# Altium schematic sheet AD9363 OSCILLATORS.SchDoc
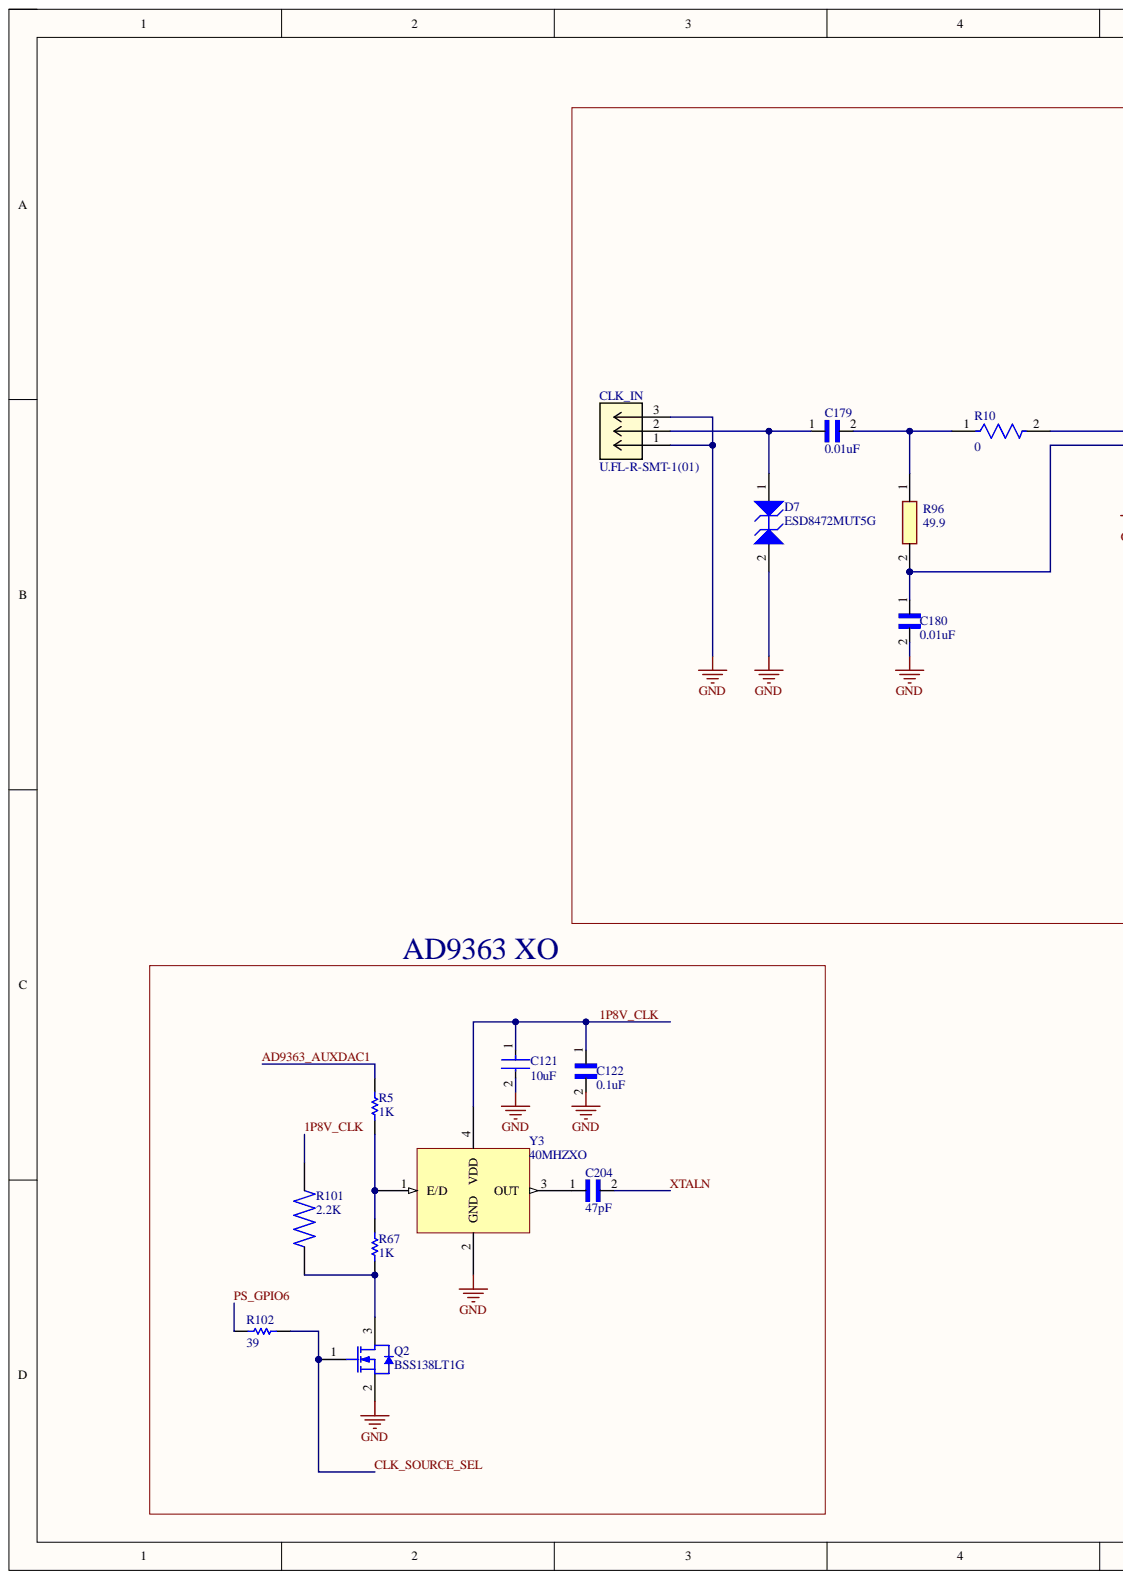
[diagram p0: AD9363 OSCILLATORS.SchDoc, left]
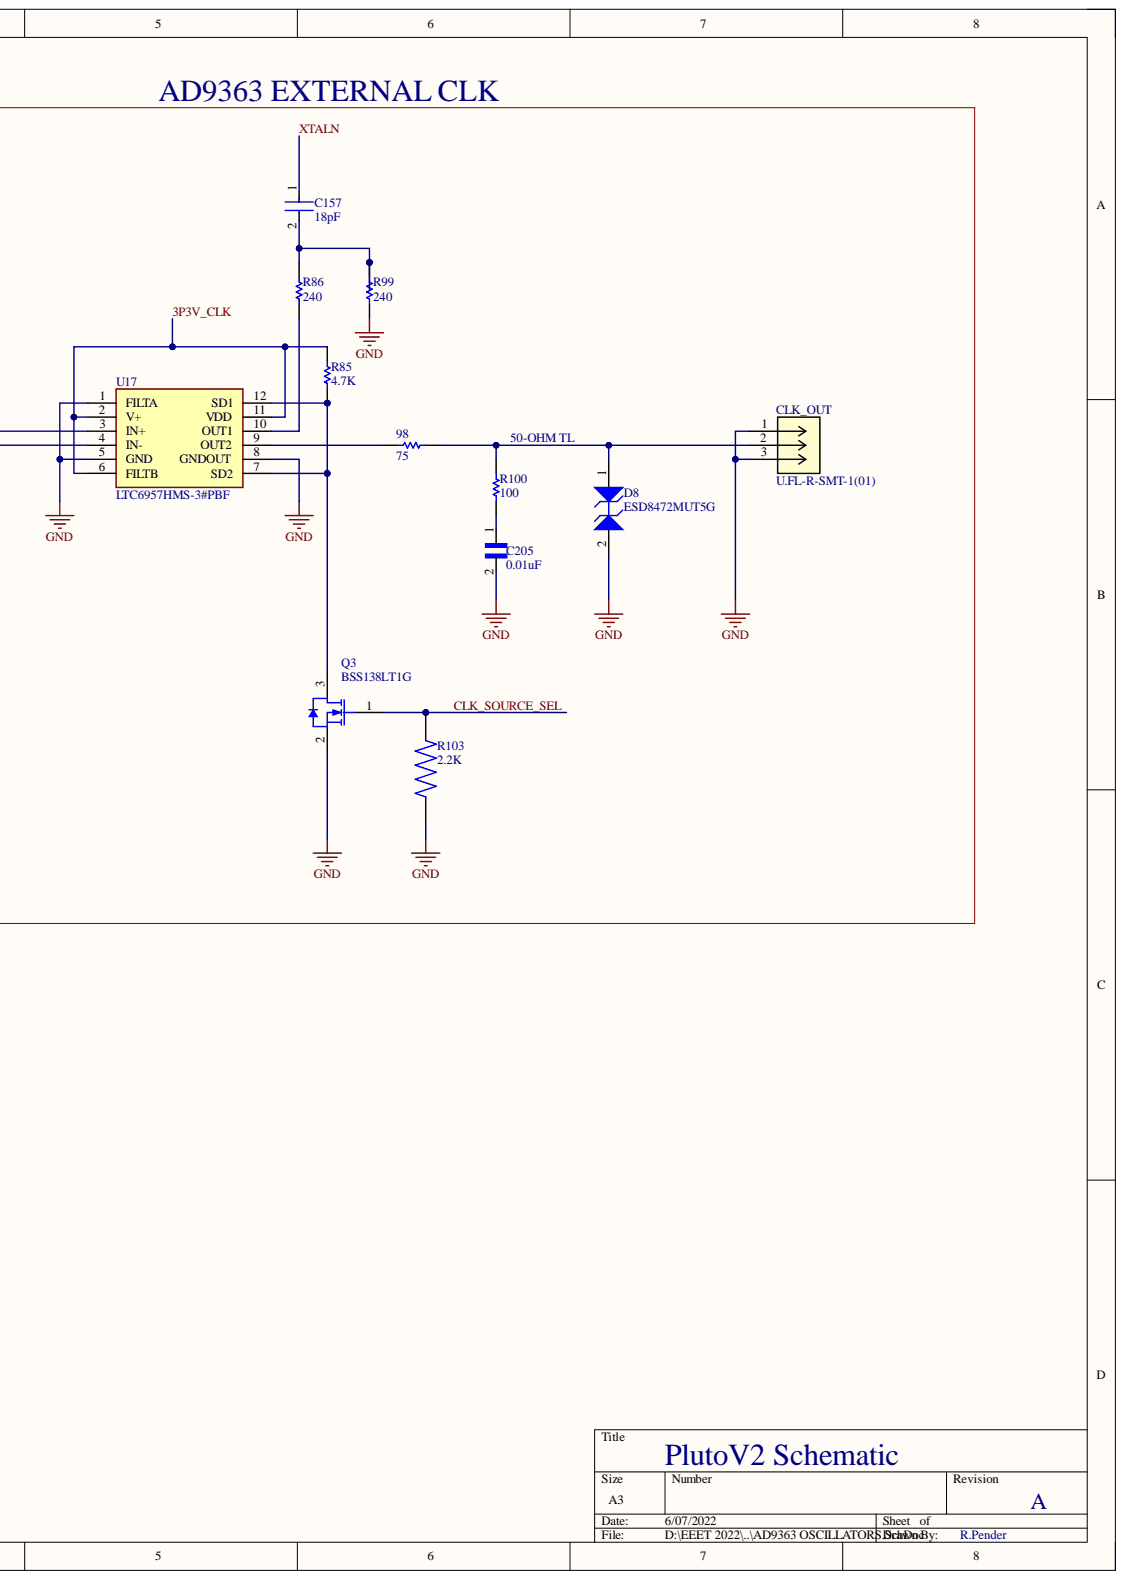
[diagram p1: AD9363 OSCILLATORS.SchDoc, right]

## Parts
98  75  [2-0402]
C121  10uF  [FP-GRM188-0_1-e0_2_0_55-IPC_C]
C122  0.1uF  [CAPC0603X33N]
C157  18pF  [FP-GRM033-0_03-IPC_A]
C179  0.01uF  [CAPC0603X33N]
C180  0.01uF  [CAPC0603X33N]
C204  47pF  [CAPC1005X55N]
C205  0.01uF  [CAPC0603X33N]
CLK_IN  U.FL-R-SMT-1(01)  [CONN_-SMT-1(01)]
CLK_OUT  U.FL-R-SMT-1(01)  [CONN_-SMT-1(01)]
D7  ESD8472MUT5G  [ONSC-X3DFN-2-152AF-01_V]
D8  ESD8472MUT5G  [ONSC-X3DFN-2-152AF-01_V]
Q2  BSS138LT1G  [ONSC-SOT-23-3-318-08_V]
Q3  BSS138LT1G  [ONSC-SOT-23-3-318-08_V]
R5  1K  [RESC0603X26X15NL03T05]
R10  0  [FP-ERJ1GN-IPC_A]
R67  1K  [2-0402]
R85  4.7K  [2-0402]
R86  240  [2-0402]
R96  49.9  [RESC1608X46N]
R99  240  [2-0402]
R100  100  [2-0402]
R101  2.2K  [RESC1005X35N]
R102  39  [2-0402]
R103  2.2K  [RESC1005X35N]
U17  LTC6957HMS-3#PBF  [SOP65P490X110-12N]
Y3  40MHZXO

## Nets
GND: C121.2 C122.2 C180.2 C205.2 CLK_IN.1 CLK_IN.3 CLK_OUT.1 CLK_OUT.3 D7.2 D8.2 Q2.2 Q3.2 R99.1 R103.2 U17.1(FILTA) U17.5(GND) U17.8(GNDOUT) Y3.2(GND)
1P8V_CLK: C121.1 C122.1 R101.2 Y3.4(VDD)
3P3V_CLK: R85.2 U17.2(V+) U17.6(FILTB) U17.11(VDD)
AD9363_AUXDAC1: R5.1
CLK_SOURCE_SEL: Q2.1 Q3.1 R102.2 R103.1
PS_GPIO6: R102.1
XTALN: C157.1 C204.2
Net98_1: 98.1 U17.9(OUT2)
Net98_2: 98.2 CLK_OUT.2 D8.1 R100.1
NetC157_2: C157.2 R86.2 R99.2
NetC179_1: C179.1 CLK_IN.2 D7.1
NetC179_2: C179.2 R10.1 R96.1
NetC180_1: C180.1 R96.2 U17.4(IN-)
NetC204_1: C204.1 Y3.3(OUT)
NetC205_1: C205.1 R100.2
NetQ2_3: Q2.3 R67.2 R101.1
NetQ3_3: Q3.3 R85.1 U17.7(SD2) U17.12(SD1)
NetR5_2: R5.2 R67.1 Y3.1(E/D)
NetR10_2: R10.2 U17.3(IN+)
NetR86_1: R86.1 U17.10(OUT1)

## Library data of the parts
98 R67 R85 R86 R99 R100 = ERJ2RK: Precision Thick Film Chip Resistor, 10 Ohm to 1M Ohm Range, 1% and 0.5% Tolerance, 0402 Size, 0.063 W; Code_IPC: 1005 [0402]; Component Kind: Standard; ComponentLink1Description: Manufacturer Link; ComponentLink1URL: http://www.panasonic.com/industrial/components/resistive_products.htm; ComponentLink2Description: Datasheet; ComponentLink2URL: http://www.panasonic.com/industrial/components/pdf/AOA0000CE2.pdf; LatestRevisionDate: 19-Mar-2004; LatestRevisionNote: Silkscreen size reduced.; Library Name: Panasonic Resistor.IntLib; PackageDocument: Mar-2003; PackageReference: 2-0402; Published: 17-Dec-2003; Signal Integrity: Res; Simulation: RESISTOR; Publisher: Altium Limited
C121 = CMP-2006-02792-2: Chip Multilayer Ceramic Capacitors for General Purpose, 0603, 10uF, X5R, 15%, 20%, 6.3V; Capacitance: 10uF; Case Code (Imperial): 0603; Case Code (Metric): 1608; Case/Package: 0603; Component Kind: Standard; Depth: 0.8mm; Dielectric: X5R; Height: 0.8mm; Lead Free: Lead Free; Length: 1.6mm; Library Name: Altium Content Vault; Material: Ceramic; Max Operating Temperature: 85°C; Min Operating Temperature: -55°C; Mount: Surface Mount; Package Quantity: 4000; Packaging: Tape and Reel; Radiation Hardening: No; REACH SVHC: No SVHC; Termination: SMD/SMT; Thickness: 0.035inch; Tolerance: 20%; Voltage: 6.3V; Voltage Rating: 6.3V; Voltage Rating (DC): 6.3V; Width: 0.031inch
C122 = GRM033R61C104ME84D: CAP 0.1UF 16VDC X5R 20% SMD 0201 PAPER TAPE; Availability: Unavailable; Component Kind: Standard; Library Name: GRM033R61C104ME84D.IntLib; MP: GRM033R61C104ME84D; Package: None; Price: None
C157 = CMP-2010-01507-2: Chip Multilayer Ceramic Capacitors for General Purpose, 0201, 18pF, C0G, 30ppm/°C, 5%, 50V; Capacitance: 18pF; Case Code (Imperial): 0201; Case Code (Metric): 0603; Case/Package: 0201; Component Kind: Standard; Depth: 0.3mm; Dielectric: C0G; Height: 0.3mm; Lead Free: Lead Free; Length: 0.6mm; Library Name: Altium Content Vault; Max Operating Temperature: 125°C; Min Operating Temperature: -55°C; Mount: Surface Mount; Package Quantity: 15000; Packaging: Tape and Reel; Radiation Hardening: No; Termination: SMD/SMT; Thickness: 0.013inch; Tolerance: 5%; Voltage: 50V; Voltage Rating: 50V; Voltage Rating (DC): 50V; Width: 0.3mm
C179 C180 C205 = GRM033R71A103KA01D: SMD Capacitor X7R(EIA) with Capacitance: 10000pF Tol. 10%. Rated Voltage: 10Vdc; MF: Murata; Availability: Unavailable; Check_prices: https://www.snapeda.com/parts/GRM033R71A103KA01D/Murata/view-part/4379446/?ref=eda; Component Kind: Standard; Library Name: GRM033R71A103KA01D.IntLib; MP: GRM033R71A103KA01D; Package: 0603 Taiyo Yuden; Price: None; SnapEDA_Link: https://www.snapeda.com/parts/GRM033R71A103KA01D/Murata/view-part/4379446/?ref=snap
C204 = GCM1555C1H470JA16D: 47pF Â±5% 50V Ceramic Capacitor C0G, NP0 0402 (1005 Metric); MF: Murata; Check_prices: https://www.snapeda.com/parts/GCM1555C1H470JA16D/Murata+Electronics+North+America/view-part/?ref=eda; Component Kind: Standard; Digi-Key_Part_Number: 490-10670-2-ND; Library Name: GCM1555C1H470JA16D.IntLib; MP: GCM1555C1H470JA16D; Package: 1005 Taiyo Yuden; Purchase-URL: https://pricing.snapeda.com/search/part/GCM1555C1H470JA16D/?ref=eda; SnapEDA_Link: https://www.snapeda.com/parts/GCM1555C1H470JA16D/Murata+Electronics+North+America/view-part/?ref=snap
CLK_IN CLK_OUT = U.FL-R-SMT-1(01): No Description Available; Mfr_Name: Hirose; Component Kind: Standard; Digi-Key_Part_Number_1: H122041-ND; Digi-Key_Part_Number_2: H122041-NDL; Manufacturer_Part_Number: U.FL-R-SMT-1(01); RefDes: RefDes; Type: DEV; Copyright: Copyright (C) 2022 Ultra Librarian. All rights reserved.; Library Name: UPFL-R-SMT-1_01_.SchLib
D7 D8 = CMP-1063-00281-1: Ultra-Low Capacitance ESD Protection, Micro-Packaged Diode for ESD Protection, 2-Pin X3DFN, Pb-Free, Tape and Reel; Manufacturer: On Semiconductor; C Max (pF): 0.3; Direction: Bidirectional; IR Max (uA): 0.05; Number of Lines: 1; V(BR) Min (V): 7; VRWM Max (V): 5.3; Component Kind: Standard; ComponentLink1Description: Manufacturer URL; ComponentLink1URL: http://www.onsemi.com/; ComponentLink2Description: Datasheet; ComponentLink2URL: http://www.onsemi.cn/pub_link/Collateral/ESD8472-D.PDF; ComponentLink3Description: Package Specification; ComponentLink3URL: http://www.onsemi.cn/pub_link/Collateral/152AF.PDF; DatasheetVersion: Rev. 4; Library Name: Altium Content Vault; Mounting Technology: Surface Mount; PackageDescription: 2-Pin Small Outline No-Lead, Body 0.62 x 0.32 mm, Pitch 0.355 mm; PackageReference: X3DFN-2-152AF-01; PackageVersion: Rev. O, 04/2011; Packing: Tape and Reel; PartNumber: ESD8472MUT5G; RoHS: Pb-Free
Q2 Q3 = CMP-1058-00767-1: Power MOSFET, 200 mA, 50 V, N-Channel, 3-Pin SOT-23, Pb-Free, Tape and Reel; Manufacturer: On Semiconductor; Channel Polarity: N Channel; Ciss Typ (pF): 40; Configuration: Single; Coss Typ (pF): 12; Crss Typ (pF): 3.5; ID Max (A): 0.2; PD Max (W): 0.225; V(BR)DSS Min (V): 50; VGS Max (V): 20; VGS(th) Max (V): 1.5; Component Kind: Standard; ComponentLink1Description: Manufacturer URL; ComponentLink1URL: http://www.onsemi.com/; ComponentLink2Description: Datasheet; ComponentLink2URL: http://www.onsemi.com/pub_link/Collateral/BSS138LT1-D.PDF; ComponentLink3Description: Package Specification; ComponentLink3URL: http://www.onsemi.cn/pub_link/Collateral/318-08.PDF; DatasheetVersion: Rev. 8; Library Name: Altium Content Vault; Mounting Technology: Surface Mount; Package Type: SOT-23-3; PackageDescription: 3-Pin Small Outline Transistor, Body 2.92 x 1.3 mm, Pitch 0.95 mm; PackageReference: SOT-23-3-318-08; PackageVersion: Rev. AP, 11/2009; Packing: Tape and Reel; PartNumber: BSS138LT1G; RoHS: Pb-Free
R5 = CMP-2004-03448-1: Manufacturer: Panasonic; Part Number: ERJ1GNF10R0C; Component Kind: Standard; Library Name: Altium Content Vault
R10 = CMP-1010-00001-3: RES SMD 0 OHM JUMPER 1/20W 0201; Max Power Dissipation: 50mW; Resistance: 0R; Temperature Coefficient: 600ppm/°C; Tolerance: 1%; Voltage Rating: 25V; Case Code (Imperial): 0201; Case Code (Metric): 0603; Case/Package: 0201; Component Kind: Standard; Construction: Rectangular; Contact Plating: Tin; Depth: 0.3mm; Features: Automotive AEC-Q200; Height: 0.23mm; Lead Free: Lead Free; Length: 0.6mm; Library Name: Altium Content Vault; Max Operating Temperature: 125°C; Military Standard: Not; Min Operating Temperature: -55°C; Mount: Surface Mount; Number of Terminations: 2; Package Quantity: 15000; Packaging: Tape and Reel; Power Rating: 50mW; Resistor Type: Thick Film; RoHS Compliant: Yes; Series: ERJ; Voltage Rating (DC): 25V; Width: 0.3mm
R96 = PCAN0603E49R9BST5: Resistor; Manufacturer_Name: Vishay; Component Kind: Standard; Datasheet Link: http://www.vishay.com/docs/60125/pcan.pdf; Height: 0.457mm; Manufacturer_Part_Number: PCAN0603E49R9BST5
R101 R103 = CRCW04022K20FKEDC: 2.2 kOhms Â±1% 0.063W, 1/16W Chip Resistor 0402 _1005 Metric_ Thick Film; MF: Vishay; Availability: Unavailable; Component Kind: Standard; Library Name: CRCW04022K20FKEDC.IntLib; MP: CRCW04022K20FKEDC; Package: 1005 Yageo; Price: None; SnapEDA_Link: https://www.snapeda.com/parts/CRCW04022K20FKEDC/Vishay/view-part/4129871/?ref=snap
R102 = ERJ2GE: Thick Film Chip Resistor, 1 Ohm to 2.2M Ohm Range, 5% Tolerance, 0402 Size, 0.063 W; Note: If flow soldering, adjust pad width of PCB Footprint to 0.7 - 0.8 times the width of the resistor.; Code_IPC: 1005 [0402]; Component Kind: Standard; ComponentLink1Description: Manufacturer Link; ComponentLink1URL: http://www.panasonic.com/industrial/components/resistive_products.htm; ComponentLink2Description: Datasheet; ComponentLink2URL: http://www.panasonic.com/industrial/components/pdf/AOA0000CE1.pdf; LatestRevisionDate: 19-Mar-2004; LatestRevisionNote: Silkscreen size reduced.; Library Name: Panasonic Resistor.IntLib; PackageDocument: Mar-2003; PackageReference: 2-0402; Published: 17-Dec-2003; Signal Integrity: Res; Simulation: RESISTOR; Publisher: Altium Limited
U17 = LTC6957HMS-3#PBF: Integrated Circuit; Manufacturer_Name: Analog Devices; Component Kind: Standard; Datasheet Link: https://componentsearchengine.com//LTC6957HMS-3#PBF.pdf; Height: 1.1mm; Manufacturer_Part_Number: LTC6957HMS-3#PBF; Mouser2 Part Number: 584-LTC6957HMS-3#PBF; Mouser2 Price/Stock: https://www.mouser.com/Search/Refine.aspx?Keyword=584-LTC6957HMS-3%23PBF
Y3 = 40MHZXO: Component Kind: Standard; Library Name: RINALDOSCHEMS.SchLib
